# Altium schematic sheet ELEC3300_Mainboard.SchDoc
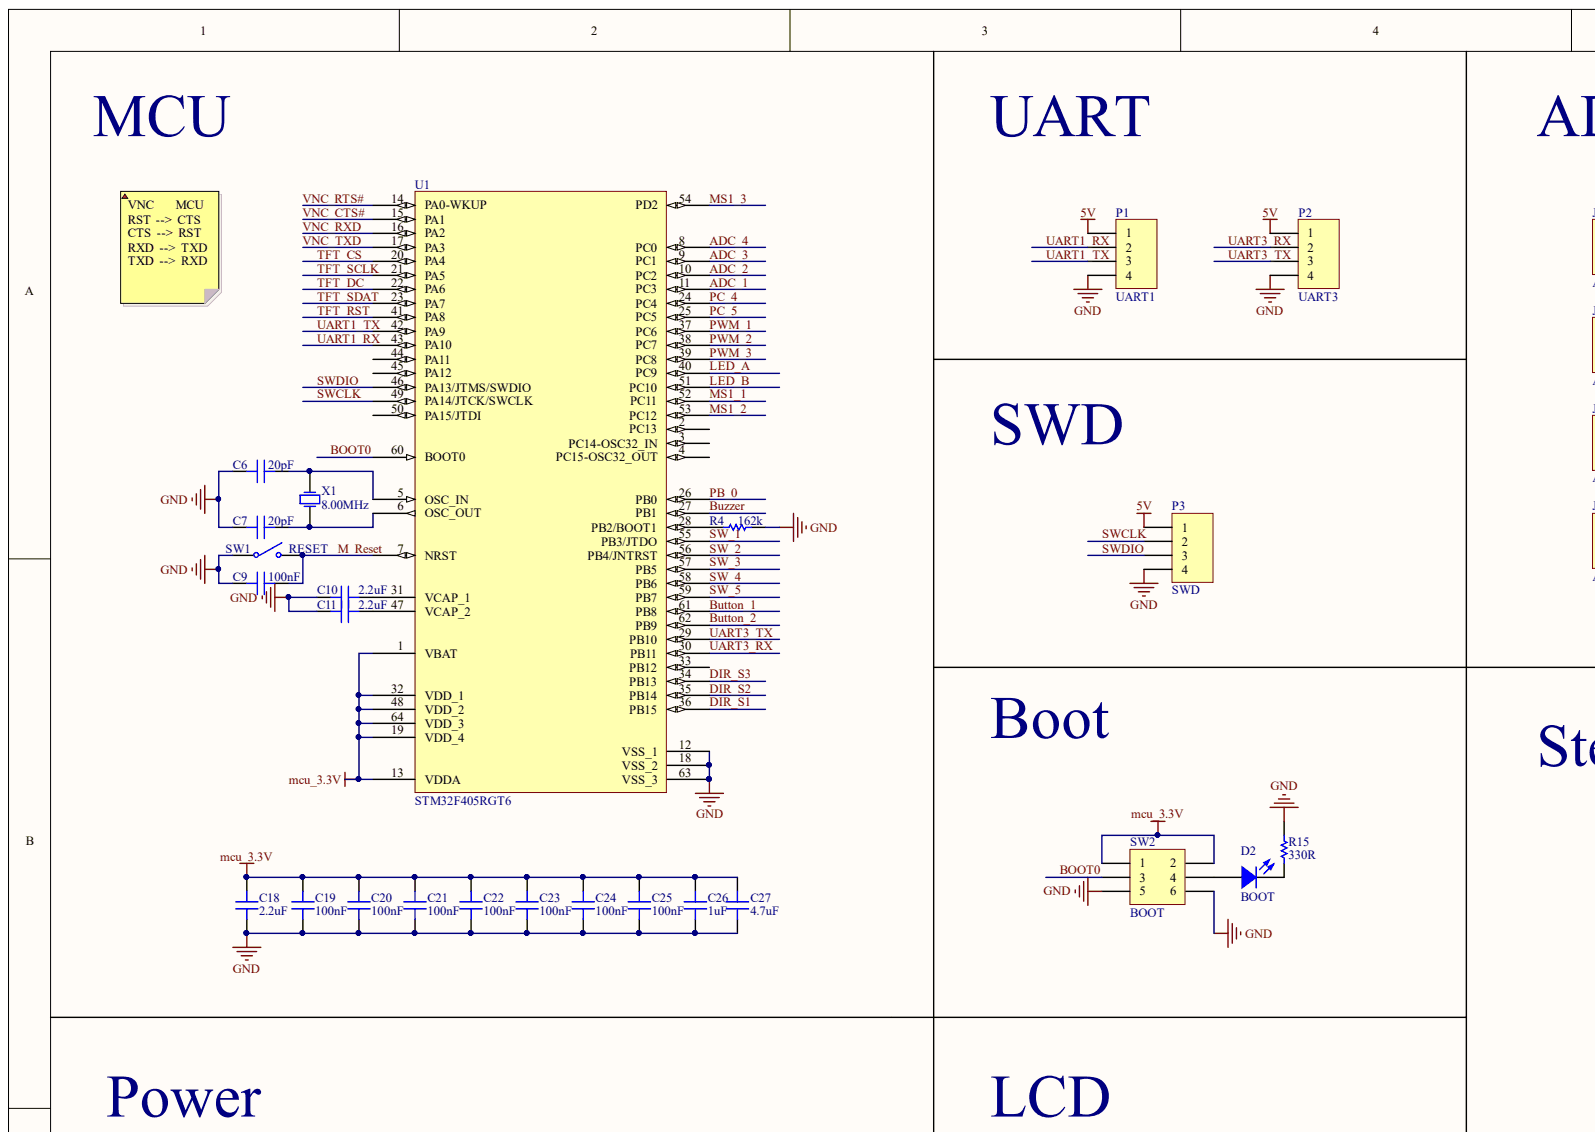
[diagram p0: ELEC3300_Mainboard.SchDoc, top left]
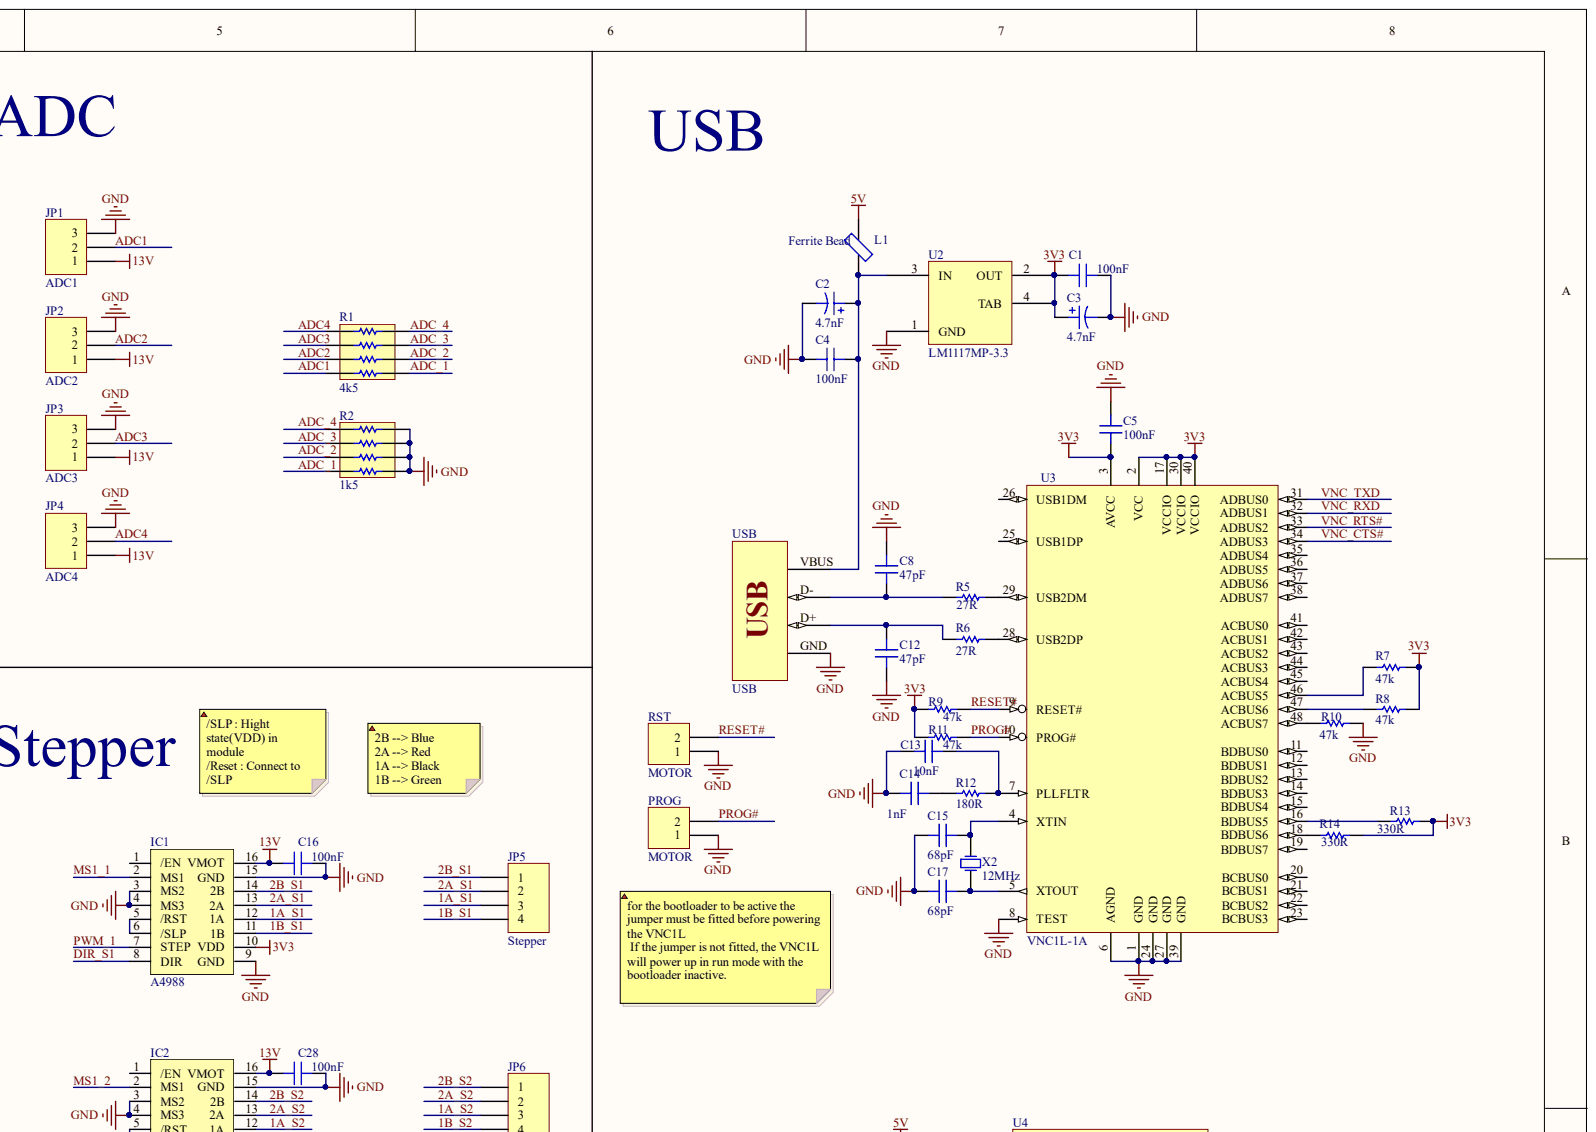
[diagram p1: ELEC3300_Mainboard.SchDoc, top right]
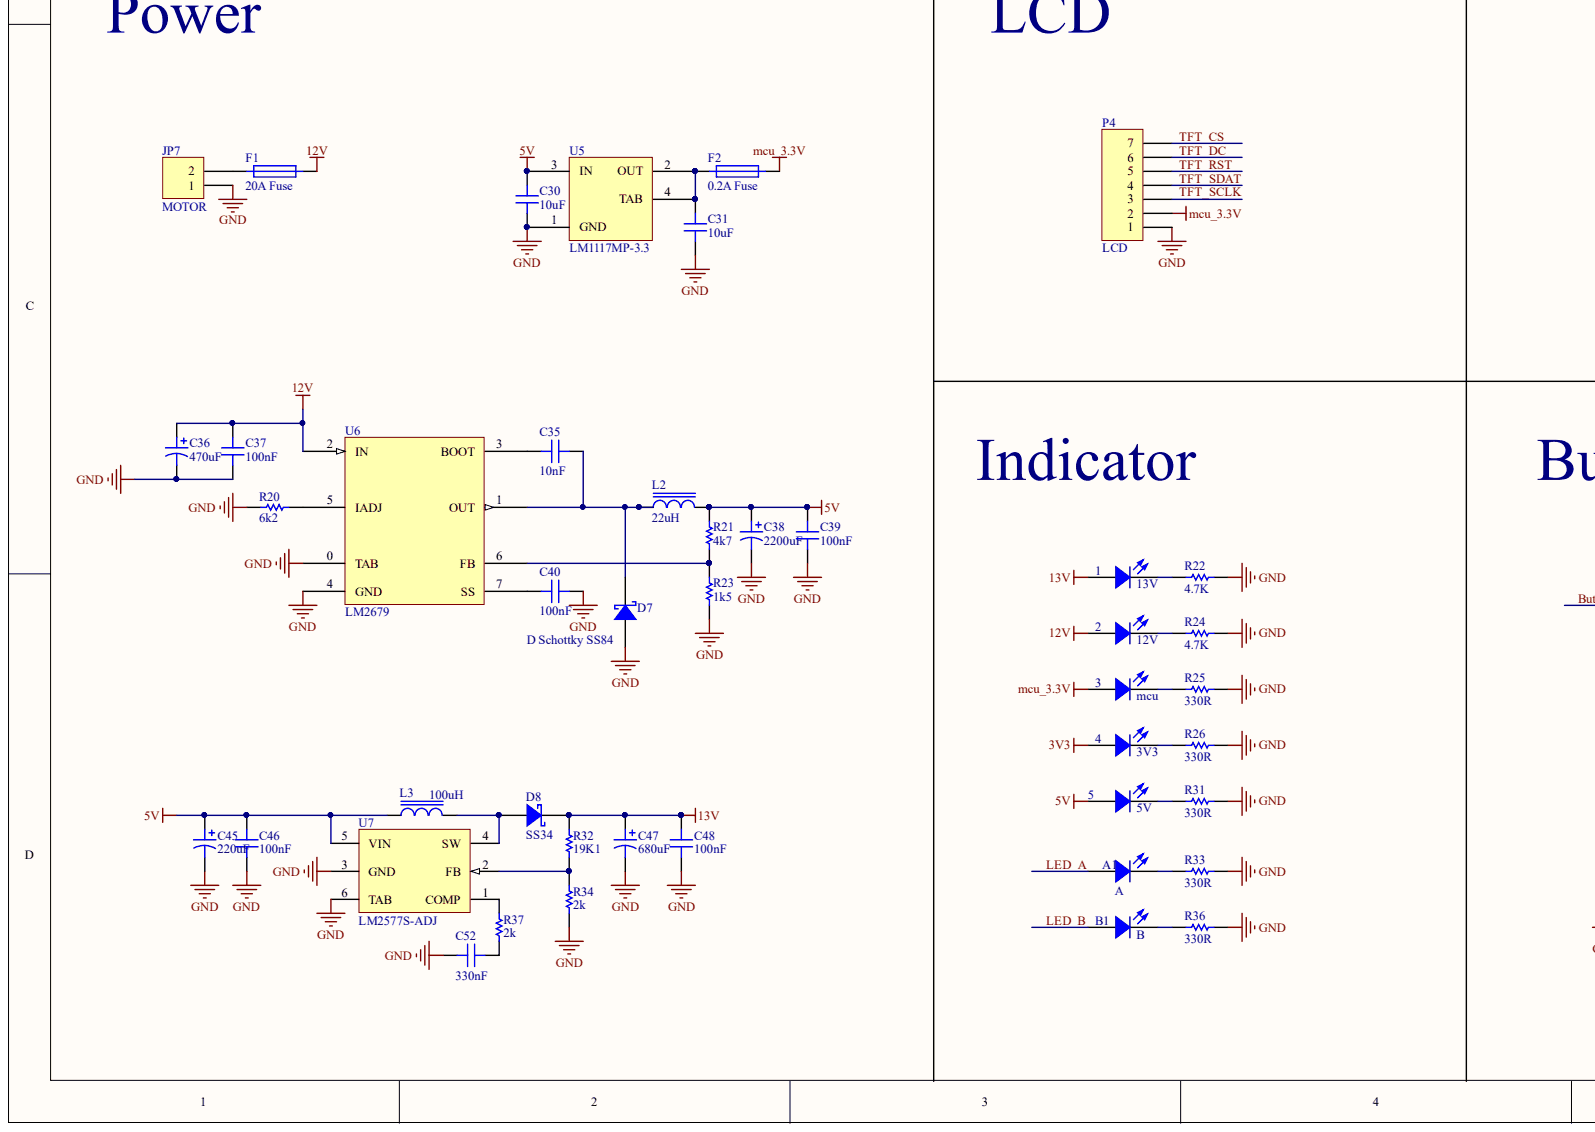
[diagram p2: ELEC3300_Mainboard.SchDoc, bottom left]
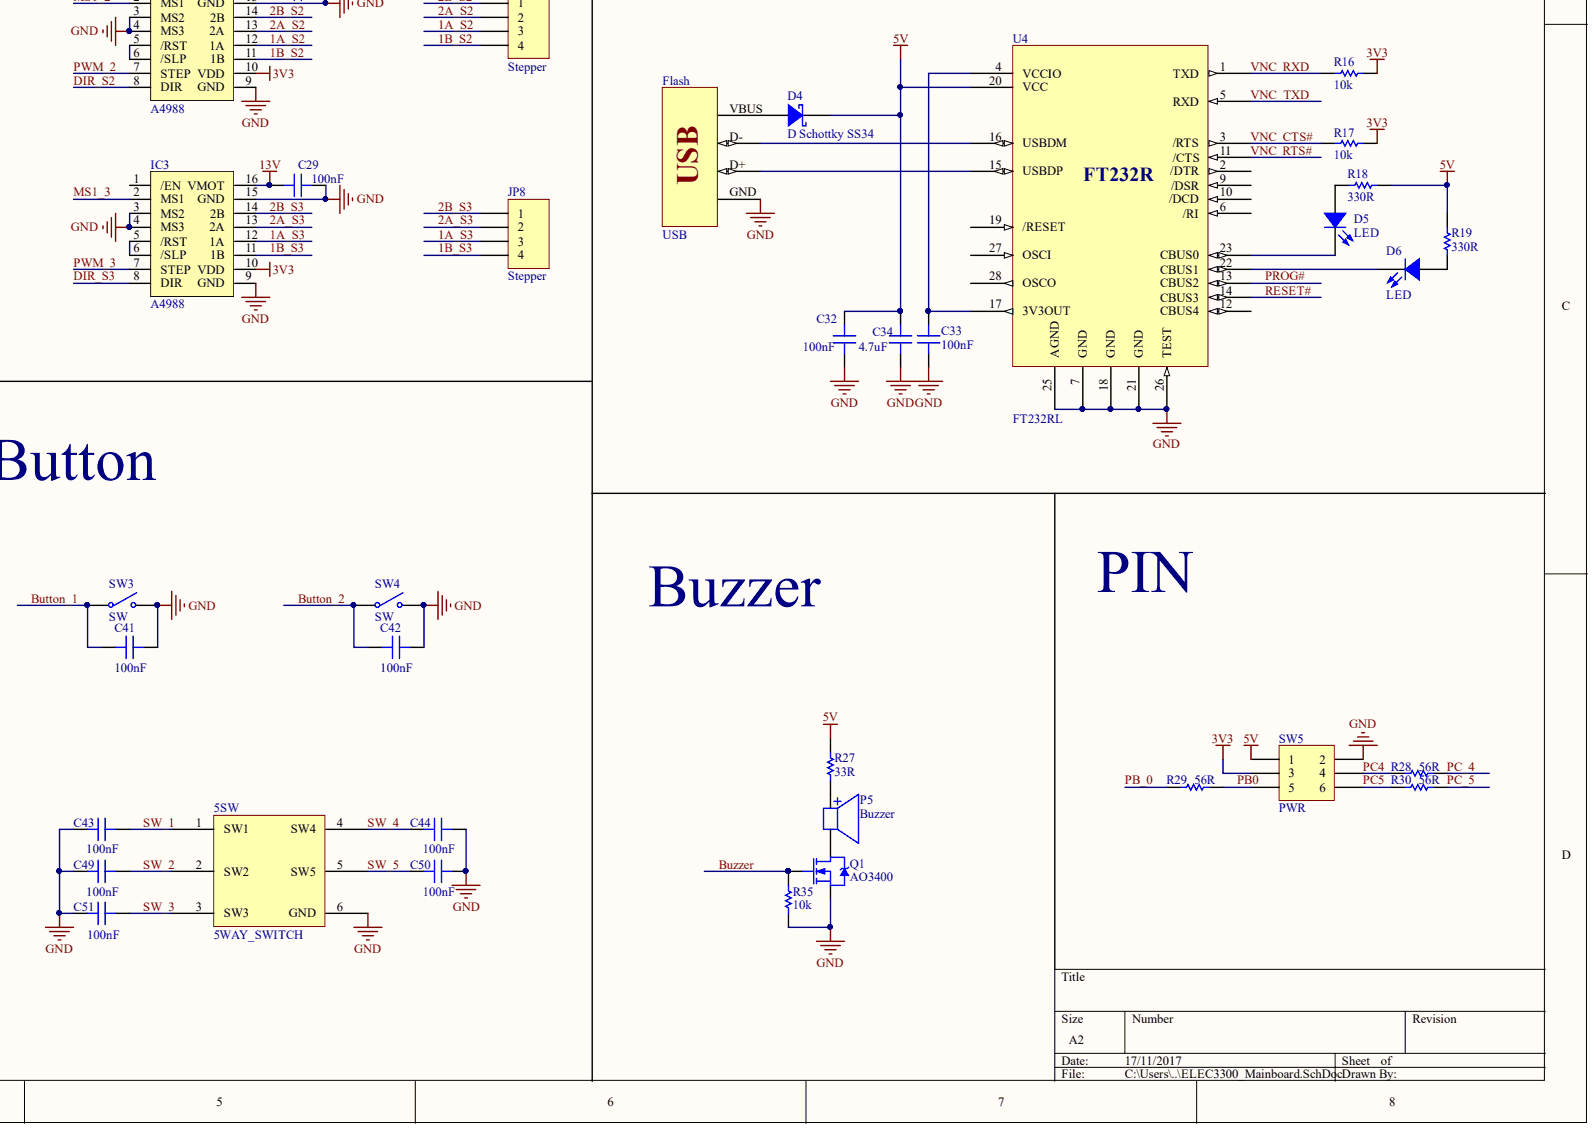
[diagram p3: ELEC3300_Mainboard.SchDoc, bottom right]

## Parts
1  13V  [D0805]
2  12V  [D0805]
3  mcu  [D0805]
4  3V3  [D0805]
5  5V  [D0805]
5SW  5WAY_SWITCH  [5WAY_SWITCH]
A1  A  [D0805]
B1  B  [D0805]
C1  100nF  [CC2012-0805]
C2  4.7nF  [EIA7343]
C3  4.7nF  [EIA7343]
C4  100nF  [CC2012-0805]
C5  100nF  [CC2012-0805]
C6  20pF  [CC2012-0805]
C7  20pF  [CC2012-0805]
C8  47pF  [CC2012-0805]
C9  100nF  [CC2012-0805]
C10  2.2uF  [CC2012-0805]
C11  2.2uF  [CC2012-0805]
C12  47pF  [CC2012-0805]
C13  10nF  [CC2012-0805]
C14  1nF  [CC2012-0805]
C15  68pF  [CC2012-0805]
C16  100nF  [CC2012-0805]
C17  68pF  [CC2012-0805]
C18  2.2uF  [CC2012-0805]
C19  100nF  [CC2012-0805]
C20  100nF  [CC2012-0805]
C21  100nF  [CC2012-0805]
C22  100nF  [CC2012-0805]
C23  100nF  [CC2012-0805]
C24  100nF  [CC2012-0805]
C25  100nF  [CC2012-0805]
C26  1uF  [CC2012-0805]
C27  4.7uF  [CC2012-0805]
C28  100nF  [CC2012-0805]
C29  100nF  [CC2012-0805]
C30  10uF  [CC2012-0805]
C31  10uF  [CC2012-0805]
C32  100nF  [CC2012-0805]
C33  100nF  [CC2012-0805]
C34  4.7uF  [CC2012-0805]
C35  10nF  [CC2012-0805]
C36  470uF  [CAP10x12]
C37  100nF  [CC2012-0805]
C38  2200uF  [SV-H]
C39  100nF  [CC2012-0805]
C40  100nF  [CC2012-0805]
C41  100nF  [CC2012-0805]
C42  100nF  [CC2012-0805]
C43  100nF  [CC2012-0805]
C44  100nF  [CC2012-0805]
C45  220uF  [SV-H]
C46  100nF  [CC2012-0805]
C47  680uF  [CAP10x12]
C48  100nF  [CC2012-0805]
C49  100nF  [CC2012-0805]
C50  100nF  [CC2012-0805]
C51  100nF  [CC2012-0805]
C52  330nF  [CC2012-0805]
D2  BOOT  [D0805]
D4  D Schottky SS34  [SMC]
D5  LED  [D0805]
D6  LED  [D0805]
D7  D Schottky SS84  [SMC]
D8  SS34  [SMC]
F1  20A Fuse  [FUSE SOCKET]
F2  0.2A Fuse  [CF3216-1206]
Flash  USB  [USB-A-H(M)]
IC1  A4988  [A4988]
IC2  A4988  [A4988]
IC3  A4988  [A4988]
JP1  ADC1  [LOCKEDHDR-3]
JP2  ADC2  [LOCKEDHDR-3]
JP3  ADC3  [LOCKEDHDR-3]
JP4  ADC4  [LOCKEDHDR-3]
JP5  Stepper  [LOCKEDHDR-4]
JP6  Stepper  [LOCKEDHDR-4]
JP7  MOTOR  [T-CONNECTOR(M)]
JP8  Stepper  [LOCKEDHDR-4]
L1  Ferrite Bead  [Ferrite Bead]
L2  22uH  [MSS1260]
L3  100uH  [MSS1260]
P1  UART1  [LOCKEDHDR-4]
P2  UART3  [LOCKEDHDR-4]
P3  SWD  [LOCKEDHDR-4]
P4  LCD  [HDR1X7]
P5  Buzzer  [BUZ-9.0]
PROG  MOTOR  [HDR1X2]
Q1  AO3400  [SO-G3/Z3.3]
R1  4k5  [ARC241-0603x4]
R2  1k5  [ARC241-0603x4]
R4  162k  [CR2012-0805]
R5  27R  [CR2012-0805]
R6  27R  [CR2012-0805]
R7  47k  [CR2012-0805]
R8  47k  [CR2012-0805]
R9  47k  [CR2012-0805]
R10  47k  [CR2012-0805]
R11  47k  [CR2012-0805]
R12  180R  [CR2012-0805]
R13  330R  [CR2012-0805]
R14  330R  [CR2012-0805]
R15  330R  [CR2012-0805]
R16  10k  [CR2012-0805]
R17  10k  [CR2012-0805]
R18  330R  [CR2012-0805]
R19  330R  [CR2012-0805]
R20  6k2  [CR2012-0805]
R21  4k7  [CR2012-0805]
R22  4.7K  [CR2012-0805]
R23  1k5  [CR2012-0805]
R24  4.7K  [CR2012-0805]
R25  330R  [CR2012-0805]
R26  330R  [CR2012-0805]
R27  33R  [CR2012-0805]
R28  56R  [CR2012-0805]
R29  56R  [CR2012-0805]
R30  56R  [CR2012-0805]
R31  330R  [CR2012-0805]
R32  19K1  [CR2012-0805]
R33  330R  [CR2012-0805]
R34  2k  [CR2012-0805]
R35  10k  [CR2012-0805]
R36  330R  [CR2012-0805]
R37  2k  [CR2012-0805]
RST  MOTOR  [HDR1X2]
SW1  RESET  [PUSH SWITCH]
SW2  BOOT  [POWER SWITCH]
SW3  SW  [PUSH SWITCH]
SW4  SW  [PUSH SWITCH]
SW5  PWR  [HDR2X3]
U1  STM32F405RGT6  [LQFP64]
U2  LM1117MP-3.3  [MP04A_L]
U3  VNC1L-1A  [LQFP-48_N]
U4  FT232RL  [SSOP-28]
U5  LM1117MP-3.3  [MP04A_N]
U6  LM2679  [TO-263(7)]
U7  LM2577S-ADJ  [TS5B_N]
USB  USB  [USB-A-H(F)]
X1  8.00MHz  [BCY-W2/E4.7]
X2  12MHz  [BCY-W2/E4.7]

## Nets
3V3: 4.1 C1.2 C3.1 C5.1 IC1.10(VDD) IC2.10(VDD) IC3.10(VDD) R7.1 R8.2 R9.1 R11.1 R13.2 R14.2 R16.2 R17.2 SW5.3 U2.2(OUT) U2.4(TAB) U3.2(VCC) U3.3(AVCC) U3.17(VCCIO) U3.30(VCCIO) U3.40(VCCIO)
5V: 5.1 C30.2 C32.2 C34.2 C38.1 C39.1 C45.1 C46.2 D4.2 L1.2 L2.2 L3.1 P1.1 P2.1 P3.1 R18.1 R19.2 R21.2 R27.2 SW5.1 U4.20(VCC) U5.3(IN) U7.5(VIN)
12V: 2.1 C36.1 C37.1 F1.2 U6.2(IN)
13V: 1.1 C16.2 C28.2 C29.2 C47.1 C48.2 D8.2 IC1.16(VMOT) IC2.16(VMOT) IC3.16(VMOT) JP1.1 JP2.1 JP3.1 JP4.1 R32.2
GND: 5SW.6(GND) C1.1 C2.2 C3.2 C4.1 C5.2 C6.1 C7.1 C8.2 C9.2 C10.2 C11.2 C12.1 C13.1 C14.1 C15.1 C16.1 C17.1 C18.1 C19.1 C20.1 C21.1 C22.1 C23.1 C24.1 C25.1 C26.1 C27.1 C28.1 C29.1 C30.1 C31.1 C32.1 C33.1 C34.1 C36.2 C37.2 C38.2 C39.2 C40.2 C41.2 C42.2 C43.1 C44.2 C45.2 C46.1 C47.2 C48.1 C49.1 C50.2 C51.2 C52.2 D7.1 Flash.GND IC1.3(MS2) IC1.4(MS3) IC1.9(GND) IC1.15(GND) IC2.3(MS2) IC2.4(MS3) IC2.9(GND) IC2.15(GND) IC3.3(MS2) IC3.4(MS3) IC3.9(GND) IC3.15(GND) JP1.3 JP2.3 JP3.3 JP4.3 JP7.1 P1.4 P2.4 P3.4 P4.1 PROG.1 Q1.2 R2.2 R2.4 R2.6 R2.8 R4.1 R10.2 R15.1 R20.1 R22.2 R23.1 R24.2 R25.2 R26.2 R31.2 R33.2 R34.1 R35.1 R36.2 RST.1 SW1.1 SW2.5 SW2.6 SW3.2 SW4.2 SW5.2 U1.12(VSS_1) U1.18(VSS_2) U1.63(VSS_3) U2.1(GND) U3.1(GND) U3.6(AGND) U3.8(TEST) U3.24(GND) U3.27(GND) U3.39(GND) U4.7(GND) U4.18(GND) U4.21(GND) U4.25(AGND) U4.26(TEST) U5.1(GND) U6.0(TAB) U6.4(GND) U7.3(GND) U7.6(TAB) USB.GND
MCU_3.3V: 3.1 C18.2 C19.2 C20.2 C21.2 C22.2 C23.2 C24.2 C25.2 C26.2 C27.2 F2.2 P4.2 SW2.1 SW2.2 U1.1(VBAT) U1.13(VDDA) U1.19(VDD_4) U1.32(VDD_1) U1.48(VDD_2) U1.64(VDD_3)
1A_S1: IC1.12(1A) JP5.3
1A_S2: IC2.12(1A) JP6.3
1A_S3: IC3.12(1A) JP8.3
1B_S1: IC1.11(1B) JP5.4
1B_S2: IC2.11(1B) JP6.4
1B_S3: IC3.11(1B) JP8.4
2A_S1: IC1.13(2A) JP5.2
2A_S2: IC2.13(2A) JP6.2
2A_S3: IC3.13(2A) JP8.2
2B_S1: IC1.14(2B) JP5.1
2B_S2: IC2.14(2B) JP6.1
2B_S3: IC3.14(2B) JP8.1
ADC1: JP1.2 R1.7
ADC2: JP2.2 R1.5
ADC3: JP3.2 R1.3
ADC4: JP4.2 R1.1
ADC_1: R1.8 R2.7 U1.11(PC3)
ADC_2: R1.6 R2.5 U1.10(PC2)
ADC_3: R1.4 R2.3 U1.9(PC1)
ADC_4: R1.2 R2.1 U1.8(PC0)
BOOT0: SW2.3 U1.60(BOOT0)
BUTTON_1: C41.1 SW3.1 U1.61(PB8)
BUTTON_2: C42.1 SW4.1 U1.62(PB9)
BUZZER: Q1.1 R35.2 U1.27(PB1)
DIR_S1: IC1.8(DIR) U1.36(PB15)
DIR_S2: IC2.8(DIR) U1.35(PB14)
DIR_S3: IC3.8(DIR) U1.34(PB13)
LED_A: A1.1 U1.40(PC9)
LED_B: B1.1 U1.51(PC10)
M_RESET: C9.1 SW1.2 U1.7(NRST)
MS1_1: IC1.2(MS1) U1.52(PC11)
MS1_2: IC2.2(MS1) U1.53(PC12)
MS1_3: IC3.2(MS1) U1.54(PD2)
PB0: R29.2 SW5.5
PB_0: R29.1 U1.26(PB0)
PC4: R28.1 SW5.4
PC5: R30.1 SW5.6
PC_4: R28.2 U1.24(PC4)
PC_5: R30.2 U1.25(PC5)
PROG#: PROG.2 R11.2 U3.10(PROG#) U4.13(CBUS2)
PWM_1: IC1.7(STEP) U1.37(PC6)
PWM_2: IC2.7(STEP) U1.38(PC7)
PWM_3: IC3.7(STEP) U1.39(PC8)
RESET#: R9.2 RST.2 U3.9(RESET#) U4.14(CBUS3)
SW_1: 5SW.1(SW1) C43.2 U1.55(PB3/JTDO)
SW_2: 5SW.2(SW2) C49.2 U1.56(PB4/JNTRST)
SW_3: 5SW.3(SW3) C51.1 U1.57(PB5)
SW_4: 5SW.4(SW4) C44.1 U1.58(PB6)
SW_5: 5SW.5(SW5) C50.1 U1.59(PB7)
SWCLK: P3.2 U1.49(PA14/JTCK/SWCLK)
SWDIO: P3.3 U1.46(PA13/JTMS/SWDIO)
TFT_CS: P4.7 U1.20(PA4)
TFT_DC: P4.6 U1.22(PA6)
TFT_RST: P4.5 U1.41(PA8)
TFT_SCLK: P4.3 U1.21(PA5)
TFT_SDAT: P4.4 U1.23(PA7)
UART1_RX: P1.2 U1.43(PA10)
UART1_TX: P1.3 U1.42(PA9)
UART3_RX: P2.2 U1.30(PB11)
UART3_TX: P2.3 U1.29(PB10)
VNC_CTS#: R17.1 U1.15(PA1) U3.34(ADBUS3) U4.3(/RTS)
VNC_RTS#: U1.14(PA0-WKUP) U3.33(ADBUS2) U4.11(/CTS)
VNC_RXD: R16.1 U1.16(PA2) U3.32(ADBUS1) U4.1(TXD)
VNC_TXD: U1.17(PA3) U3.31(ADBUS0) U4.5(RXD)
Net1_2: 1.2 R22.1
Net2_2: 2.2 R24.1
Net3_2: 3.2 R25.1
Net4_2: 4.2 R26.1
Net5_2: 5.2 R31.1
NetA1_2: A1.2 R33.1
NetB1_2: B1.2 R36.1
NetC2_1: C2.1 C4.2 L1.1 U2.3(IN) USB.VBUS
NetC6_2: C6.2 U1.5(OSC_IN) X1.2
NetC7_2: C7.2 U1.6(OSC_OUT) X1.1
NetC8_1: C8.1 R5.1 USB.D-
NetC10_1: C10.1 U1.31(VCAP_1)
NetC11_1: C11.1 U1.47(VCAP_2)
NetC12_2: C12.2 R6.1 USB.D+
NetC13_2: C13.2 R12.2 U3.7(PLLFLTR)
NetC14_2: C14.2 R12.1
NetC15_2: C15.2 U3.4(XTIN) X2.2
NetC17_2: C17.2 U3.5(XTOUT) X2.1
NetC31_2: C31.2 F2.1 U5.2(OUT) U5.4(TAB)
NetC33_2: C33.2 U4.4(VCCIO) U4.17(3V3OUT)
NetC35_1: C35.1 U6.3(BOOT)
NetC35_2: C35.2 D7.2 L2.1 U6.1(OUT)
NetC40_1: C40.1 U6.7(SS)
NetC52_1: C52.1 R37.1
NetD2_1: D2.1 SW2.4
NetD2_2: D2.2 R15.2
NetD4_1: D4.1 Flash.VBUS
NetD5_1: D5.1 R18.2
NetD5_2: D5.2 U4.23(CBUS0)
NetD6_1: D6.1 R19.1
NetD6_2: D6.2 U4.22(CBUS1)
NetD8_1: D8.1 L3.2 U7.4(SW)
NetF1_1: F1.1 JP7.2
NetFlash_D+: Flash.D+ U4.15(USBDP)
NetFlash_D-: Flash.D- U4.16(USBDM)
NetIC1_5: IC1.5(/RST) IC1.6(/SLP)
NetIC2_5: IC2.5(/RST) IC2.6(/SLP)
NetIC3_5: IC3.5(/RST) IC3.6(/SLP)
NetP5_1: P5.1 R27.1
NetP5_2: P5.2 Q1.3
NetR4_2: R4.2 U1.28(PB2/BOOT1)
NetR5_2: R5.2 U3.29(USB2DM)
NetR6_2: R6.2 U3.28(USB2DP)
NetR7_2: R7.2 U3.46(ACBUS5)
NetR8_1: R8.1 U3.47(ACBUS6)
NetR10_1: R10.1 U3.48(ACBUS7)
NetR13_1: R13.1 U3.16(BDBUS5)
NetR14_1: R14.1 U3.18(BDBUS6)
NetR20_2: R20.2 U6.5(IADJ)
NetR21_1: R21.1 R23.2 U6.6(FB)
NetR32_1: R32.1 R34.2 U7.2(FB)
NetR37_2: R37.2 U7.1(COMP)

Not connected: IC1 1(/EN); IC2 1(/EN); IC3 1(/EN); U1 2(PC13) 3(PC14-OSC32_IN) 4(PC15-OSC32_OUT) 33(PB12) 44(PA11) 45(PA12) 50(PA15/JTDI); U3 11(BDBUS0) 12(BDBUS1) 13(BDBUS2) 14(BDBUS3) 15(BDBUS4) 19(BDBUS7) 20(BCBUS0) 21(BCBUS1) 22(BCBUS2) 23(BCBUS3) 25(USB1DP) 26(USB1DM) 35(ADBUS4) 36(ADBUS5) 37(ADBUS6) 38(ADBUS7) 41(ACBUS0) 42(ACBUS1) 43(ACBUS2) 44(ACBUS3) 45(ACBUS4); U4 2(/DTR) 6(/RI) 9(/DSR) 10(/DCD) 12(CBUS4) 19(/RESET) 27(OSCI) 28(OSCO)

## Library data of the parts
1-5 A1 B1 D2 D5 D6 = LED: Typical RED GaAs LED; Component Kind: Standard; LatestRevisionDate: 08-Jul-2005; LatestRevisionNote: Mech Layer 1 removed.; Library Name: Robocon_BJT.IntLib; PackageReference: LED-1; Published: 8-Jun-2000; Publisher: Altium Limited
C1 C4-C35 C37 C39-C44 C46 C48-C52 = Cap Semi: Capacitor (Semiconductor SIM Model); Component Kind: Standard; LatestRevisionDate: 17-Jul-2002; LatestRevisionNote: Re-released for DXP Platform.; Library Name: Robocon_RCL.IntLib; PackageReference: C1206; Published: 8-Jun-2000; Signal Integrity: Cap Semi; Publisher: Altium Limited
C2 C3 C38 C45 = Cap Pol SMD: Polarized Capacitor (Axial); Component Kind: Standard; LatestRevisionDate: 17-Jul-2002; LatestRevisionNote: Re-released for DXP Platform.; Library Name: Robocon_RCL.IntLib; Published: 8-Jun-2000; Publisher: Altium Limited
C36 C47 = Cap Pol DIP: Polarized Capacitor (Radial); Component Kind: Standard; LatestRevisionDate: 17-Jul-2002; LatestRevisionNote: Re-released for DXP Platform.; Library Name: Robocon_RCL.IntLib; Published: 8-Jun-2000; Publisher: Altium Limited
D4 D7 D8 = D Schottky: Schottky Diode; Code - JEDEC: DO-214-AA; Component Kind: Standard; Library Name: Robocon_BJT.IntLib; Published: 8-Jun-2000; Revision: July-2002: Re-released for DXP Platform.; Publisher: Altium Limited
F1 F2 = Fuse: Component Kind: Standard; Description: Fuse; Library Name: Robocon_RCL.IntLib; Published: 8-Jun-2000; Revision: July-2002: Re-released for DXP Platform.; Publisher: Altium Limited
Flash USB = USB Series A/B: Component Kind: Standard; Library Name: Robocn_connectors.IntLib
JP1-JP4 = Header 3: Header, 3-Pin; Component Kind: Standard; Library Name: Robocn_connectors.IntLib; Revision: 17-Jul-2002: Re-released for DXP Platform.; Signal Integrity: Connector; Publisher: Altium Limited
JP5 JP6 JP8 P1-P3 = Header 4: Header, 4-Pin; Component Kind: Standard; Library Name: Robocn_connectors.IntLib; Revision: 17-Jul-2002: Re-released for DXP Platform.; Signal Integrity: Connector; Publisher: Altium Limited
JP7 PROG RST = Header 2: Header, 2-Pin; Component Kind: Standard; Library Name: Robocn_connectors.IntLib; Revision: 17-Jul-2002: Re-released for DXP Platform.; Signal Integrity: Connector; Publisher: Altium Limited
L2 L3 = Inductor: Value: 10uH; Component Kind: Standard; Description: Inductor; Library Name: Robocon_RCL.IntLib; Published: 8-Jun-2000; Revision: July-2002: Re-released for DXP Platform.; Publisher: Altium Limited
P4 = Header 7: Header, 7-Pin; Component Kind: Standard; LatestRevisionDate: 17-Jul-2002; LatestRevisionNote: Re-released for DXP Platform.; Library Name: Miscellaneous Connectors.IntLib; Signal Integrity: Connector; Publisher: Altium Limited
Q1 = AO3400: N-Channel MOSFET; Component Kind: Standard; Library Name: Robocon_BJT.IntLib; Published: 8-Jun-2000; Revision: July-2002: Re-released for DXP Platform.; Publisher: Altium Limited
R1 R2 = Res Array: 4x Resistor Array; Component Kind: Standard; LatestRevisionDate: 17-Jul-2002; LatestRevisionNote: Re-released for DXP Platform.; Library Name: Robocon_RCL.IntLib; Published: 8-Jun-2000; Signal Integrity: Res Semi; Publisher: Altium Limited
R4-R37 = Res Semi: Semiconductor Resistor; Component Kind: Standard; LatestRevisionDate: 17-Jul-2002; LatestRevisionNote: Re-released for DXP Platform.; Library Name: Robocon_RCL.IntLib; Published: 8-Jun-2000; Signal Integrity: Res Semi; Publisher: Altium Limited
SW1 SW3 SW4 = SW-SPST: Single-Pole, Single-Throw Switch; Component Kind: Standard; Library Name: Robocn_connectors.IntLib; Published: 24-Mar-1999; Revision: July-2002: Re-released for DXP Platform.; Publisher: Altium Limited
SW2 SW5 = Header 3X2: Header, 3-Pin, Dual row; Component Kind: Standard; Library Name: Robocn_connectors.IntLib; Revision: 17-Jul-2002: Re-released for DXP Platform.; Signal Integrity: Connector; Publisher: Altium Limited
U2 U5 = LM1117MP-3.3: 800mA Low-Dropout Linear Regulator; Manufacturer: National Semiconductor; CompType: LDO IC; PackageTopMarking: XYTT*N05A; Code_IPC: SOT223-4; Code_JEDEC: TO-261-AA; Component Kind: Standard; ComponentLink1Description: LM1117 Datasheet; ComponentLink1URL: http://www.national.com/ds/LM/LM1117.pdf; ComponentLink2Description: LM1117 Web Page; ComponentLink2URL: http://www.national.com/pf/LM/LM1117.html; ComponentLink3Description: MP04A Package Page; ComponentLink3URL: http://www.national.com/packaging/folders/mp04a.html; DatasheetVersion: Apr-2006; DigiKeyPartNumber: LM1117MP-3.3CT-ND; PackageDescription: Molded SOT-223, 6.50 x 3.56 x 1.6mm Body, 4 Lead, 2.3mm Pitch; PackageReference: MP04A; PackageVersion: revB, Apr-2003; PartNumber: LM1117MP-3.3; PinCount: 4; Published: 27-Nov-2008; RoHS: O; Publisher: Altium Limited
  U2: Library Name: RoboconIC.SchLib
  U5: Library Name: Robocon_IC.IntLib
U3 = VNC1L-1A: Vinculum Embedded USB Host Controller Chip, LQFP-48; Component Kind: Standard; ComponentLink1Description: Manufacturer Link; ComponentLink1URL: http://www.ftdichip.com/Products/ICs/VNC1L.htm; ComponentLink2Description: Datasheet; ComponentLink2URL: http://www.ftdichip.com/Support/Documents/DataSheets/ICs/DS_VNC1L.pdf; DatasheetVersion: ver 2.01, May-2009; Library Name: FTDI Communication USB.IntLib; PackageDescription: 48-Pin Thin Quad Flat Pack 0.5 mm Pitch; PackageReference: LQFP-48; PackageVersion: 2005; Published: 19-Aug-2010; Publisher: Altium Limited
U4 = FT232RL: USB to UART Communication Chip; Component Kind: Standard; Library Name: Robocon_IC.IntLib
U6 = LM2679: 5A Step-Down Switching Mode Voltage Regulator; Component Kind: Standard; Library Name: Robocon_IC.IntLib
U7 = LM2577S-12: SIMPLE SWITCHER; Step-Up Voltage Regulator; Manufacturer: National Semiconductor; PackageTopMarking: NSUZXYTT*LM2577S*-12 P+; Code_JEDEC: MO-169-AB; Component Kind: Standard; ComponentLink1Description: LM2577 Datasheet; ComponentLink1URL: http://www.national.com/ds/LM/LM2577.pdf; ComponentLink2Description: LM2577 Web Page; ComponentLink2URL: http://www.national.com/pf/LM/LM2577.html; ComponentLink3Description: TS5B Package Page; ComponentLink3URL: http://www.national.com/packaging/folders/ts5b.html; CompType: Switcher; DatasheetVersion: Apr-2005; DigiKeyPartNumber: LM2577S-12-ND; Library Name: Robocon_IC.IntLib; PackageDescription: TO-263, Molded, .400 x .390 x .180in, 5 Lead, .067in Pitch, Surface Mount; PackageReference: TS5B; PackageVersion: revD, Apr-2005; PartNumber: LM2577S-12; PinCount: 5; Published: 27-Nov-2008; RoHS: Y; Publisher: Altium Limited
X1 X2 = XTAL: Crystal Oscillator; Component Kind: Standard; Library Name: Robocon_RCL.IntLib; Published: 8-Jun-2000; Revision: July-2002: Re-released for DXP Platform.; Publisher: Altium Limited
5SW = 5WAY_SWITCH: Component Kind: Standard; Library Name: SmartCar2015_Connectors.IntLib
IC1-IC3 = A4988: Component Kind: Standard; Library Name: A4988.SchLib
L1 = Ferrite Bead: Component Kind: Standard; Description: Ferrite Bead; Library Name: Ferrite_Bead.SchLib
P5 = Buzzer: Component Kind: Standard; Library Name: Robocon_RCL.IntLib
U1 = STM32F405RGT6: Component Kind: Standard; Library Name: STM32F405RGT6.SchLib
